# Altium schematic sheet 8_JTAG1_FLASH1_PMOD.SchDoc(8_JTAG1_FLASH1_PMOD)
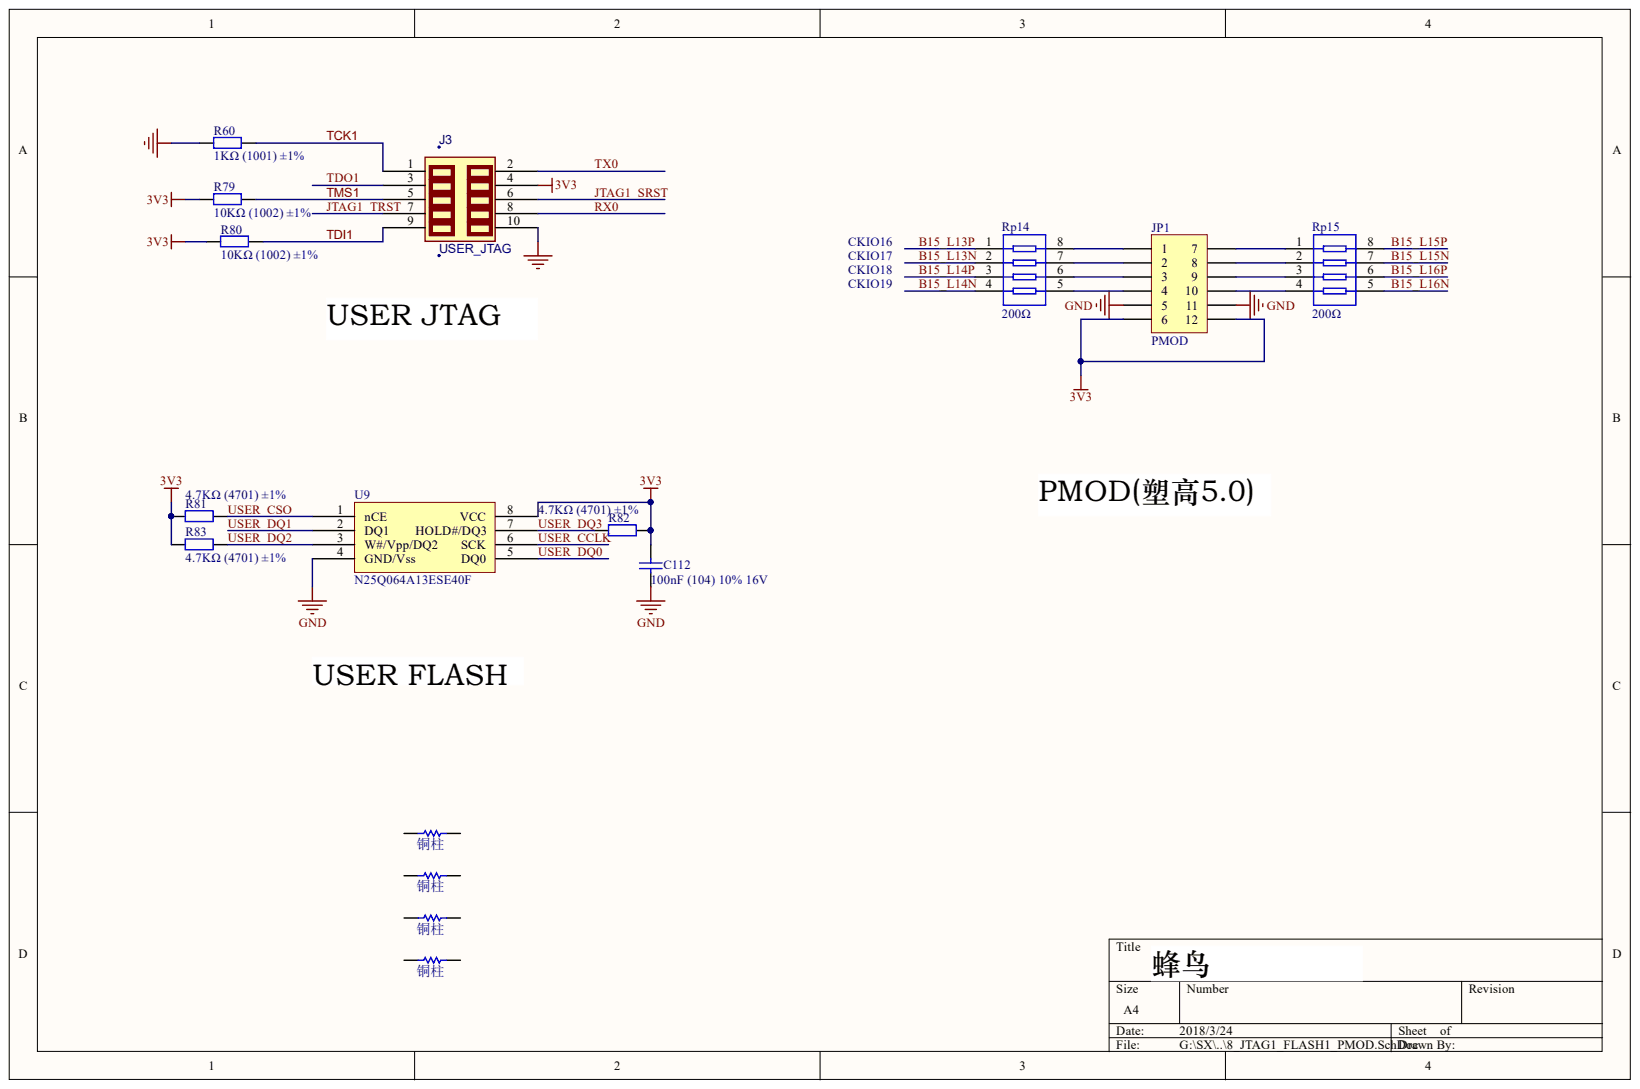
[diagram p0: 8_JTAG1_FLASH1_PMOD.SchDoc]

## Parts
C112  100nF (104) 10% 16V  [0402_C]
J3  USER_JTAG  [DC-2.54-L-Z-10P]
JP1  PMOD  [PMOD]
R60  1KΩ (1001) ±1%  [0402_R]
R79  10KΩ (1002) ±1%  [0402_R]
R80  10KΩ (1002) ±1%  [0402_R]
R81  4.7KΩ (4701) ±1%  [0402_R]
R82  4.7KΩ (4701) ±1%  [0402_R]
R83  4.7KΩ (4701) ±1%  [0402_R]
Rp14  200Ω  [0402_Rx4]
Rp15  200Ω  [0402_Rx4]
U9  N25Q064A13ESE40F  [SO8W_208mil body]
  铜柱  [FPAD_1]
  铜柱  [FPAD_1]
  铜柱  [FPAD_1]
  铜柱  [FPAD_1]

## Nets
3V3: C112.2 J3.4 JP1.6 JP1.12 R79.1 R80.1 R81.1 R82.2 R83.1 U9.8(VCC)
GND: C112.1 J3.10 JP1.5 JP1.11 R60.1 U9.4(GND/Vss)
Net_1: .1
Net_2: .2
B15_L13N: Rp14.2
B15_L13P: Rp14.1
B15_L14N: Rp14.4
B15_L14P: Rp14.3
B15_L15N: Rp15.7
B15_L15P: Rp15.8
B15_L16N: Rp15.5
B15_L16P: Rp15.6
JTAG1_SRST: J3.6
JTAG1_TRST: J3.7
RX0: J3.8
TCK1: J3.1 R60.2
TDI1: J3.9 R80.2
TDO1: J3.3
TMS1: J3.5 R79.2
TX0: J3.2
USER_CCLK: U9.6(SCK)
USER_CSO: R81.2 U9.1(nCE)
USER_DQ0: U9.5(DQ0)
USER_DQ1: U9.2(DQ1)
USER_DQ2: R83.2 U9.3(W#/Vpp/DQ2)
USER_DQ3: R82.1 U9.7(HOLD#/DQ3)
NetJP1_1: JP1.1 Rp14.8
NetJP1_2: JP1.2 Rp14.7
NetJP1_3: JP1.3 Rp14.6
NetJP1_4: JP1.4 Rp14.5
NetJP1_7: JP1.7 Rp15.1
NetJP1_8: JP1.8 Rp15.2
NetJP1_9: JP1.9 Rp15.3
NetJP1_10: JP1.10 Rp15.4

## Library data of the parts
C112 = 0402 100nF (104) 10% 16V: 贴片电容; Component Kind: Standard; ComponentLink1Description: 供应商链接; ComponentLink1URL: http://www.szlcsc.com/product/details_1877.html; Library Name: Miscellaneous Devices LC.IntLib; Package: 0402; Supplier: LC; SuppliersPartNumber: C1525
J3 = JTAG-10_Dup1: Component Kind: Standard
JP1 = PMOD: Header, 2*6Pin,; Component Kind: Standard; Revision: 17-Jul-2002: Re-released for DXP Platform.; Library Name: Personal_Accumulation_Sch.SchLib; Publisher: Altium Limited
R60 = 0402 1KΩ (1001) 1%: 贴片电阻; Component Kind: Standard; ComponentLink1Description: 供应商链接; ComponentLink1URL: http://www.szlcsc.com/product/details_12256.html; Library Name: Miscellaneous Devices LC.IntLib; Package: 0402; Supplier: LC; SuppliersPartNumber: C11702
R79 R80 = 0402 10KΩ (1002) 1%: 贴片电阻; Component Kind: Standard; ComponentLink1Description: 供应商链接; ComponentLink1URL: http://www.szlcsc.com/product/details_26487.html; Library Name: Miscellaneous Devices LC.IntLib; Package: 0402; Supplier: LC; SuppliersPartNumber: C25744
R81-R83 = 0402 4.7KΩ (4701) 1%: 贴片电阻; Component Kind: Standard; ComponentLink1Description: 供应商链接; ComponentLink1URL: http://www.szlcsc.com/product/details_26643.html; Library Name: Miscellaneous Devices LC.IntLib; Package: 0402; Supplier: LC; SuppliersPartNumber: C25900
Rp14 Rp15 = 0402*4 33Ω (330) 5%: 贴片排阻; Component Kind: Standard; ComponentLink1Description: 供应商链接; ComponentLink1URL: http://www.szlcsc.com/product/details_26244.html; Library Name: Miscellaneous Devices LC.IntLib; Package: 0402*4; Supplier: LC; SuppliersPartNumber: C25501
U9 = N25Q064A13ESE40F: FLASH芯片; Component Kind: Standard; Library Name: N25Q064A13ESE40F.SchLib
    = Res1: Resistor; Component Kind: Standard; LatestRevisionDate: 17-Jul-2002; LatestRevisionNote: Re-released for DXP Platform.; Library Name: Miscellaneous Devices.IntLib; PackageDescription: Axial Device, Thru-Hole; 2 Leads; 0.3 in Pin Spacing; PackageReference: AXIAL-0.3; Published: 8-Jun-2000; Simulation: RESISTOR; Publisher: Altium Limited
